# Altium schematic sheet Power - Switch Power.SchDoc("Power - Switch Power")
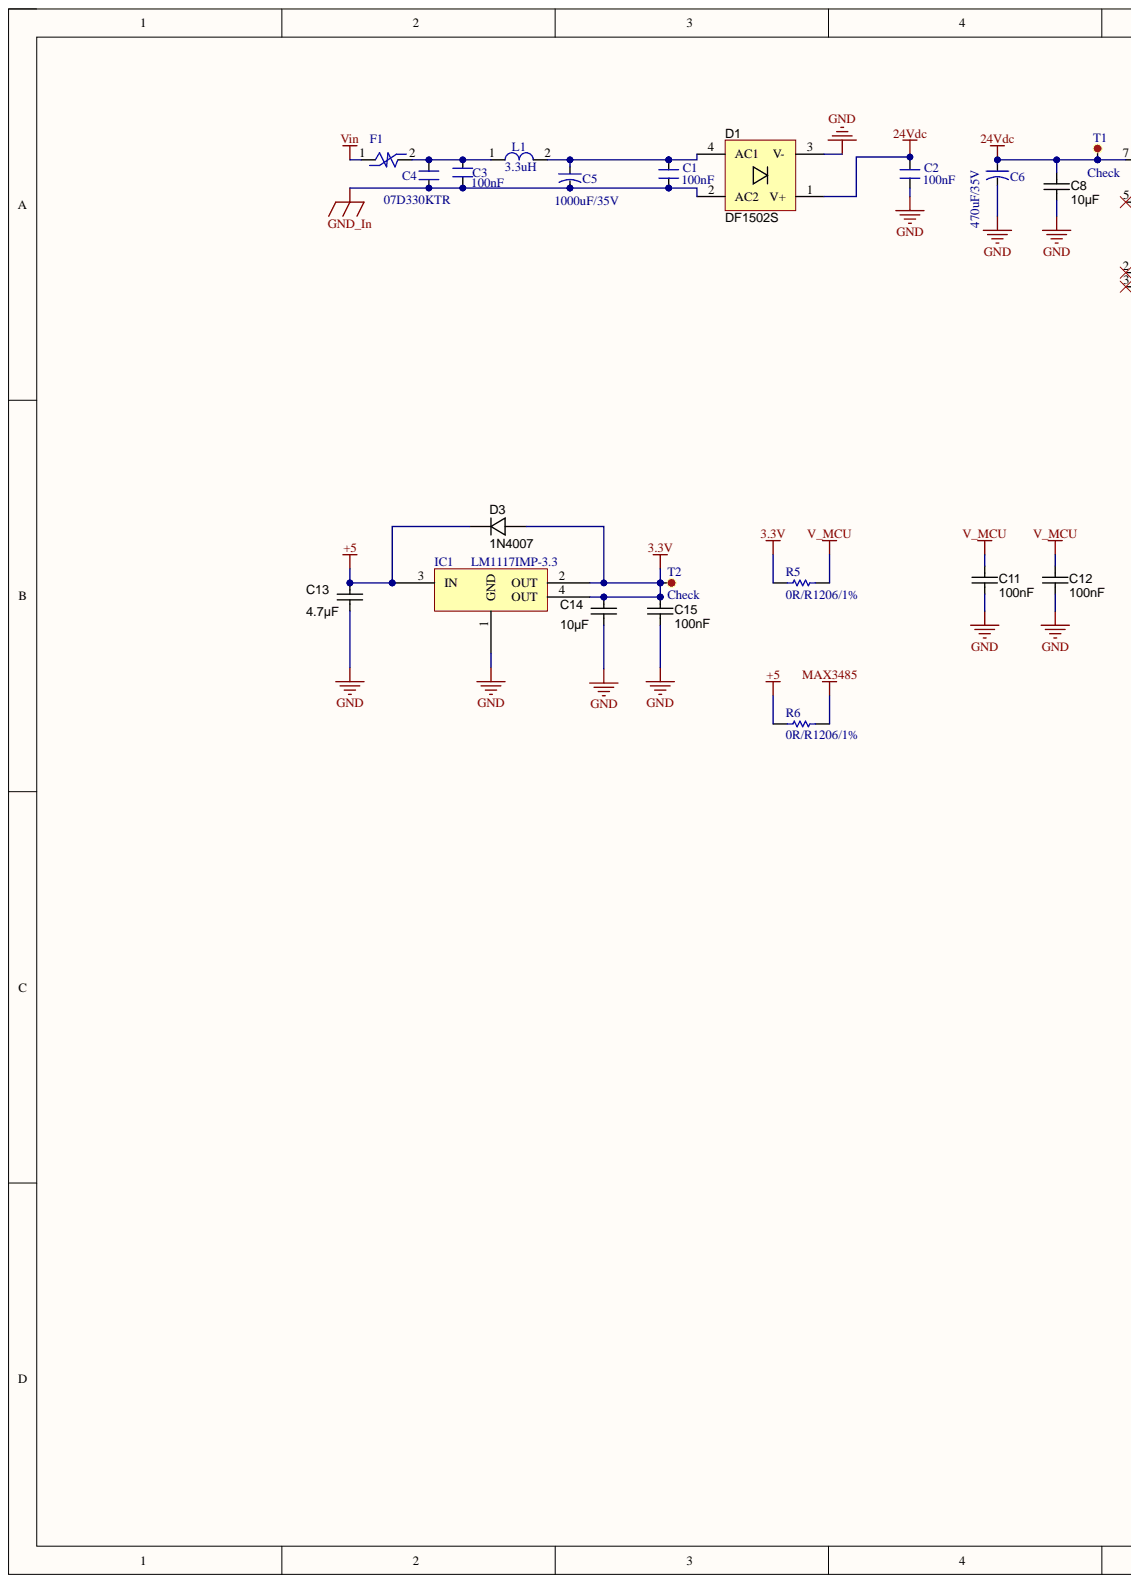
[diagram p0: Power - Switch Power.SchDoc, left]
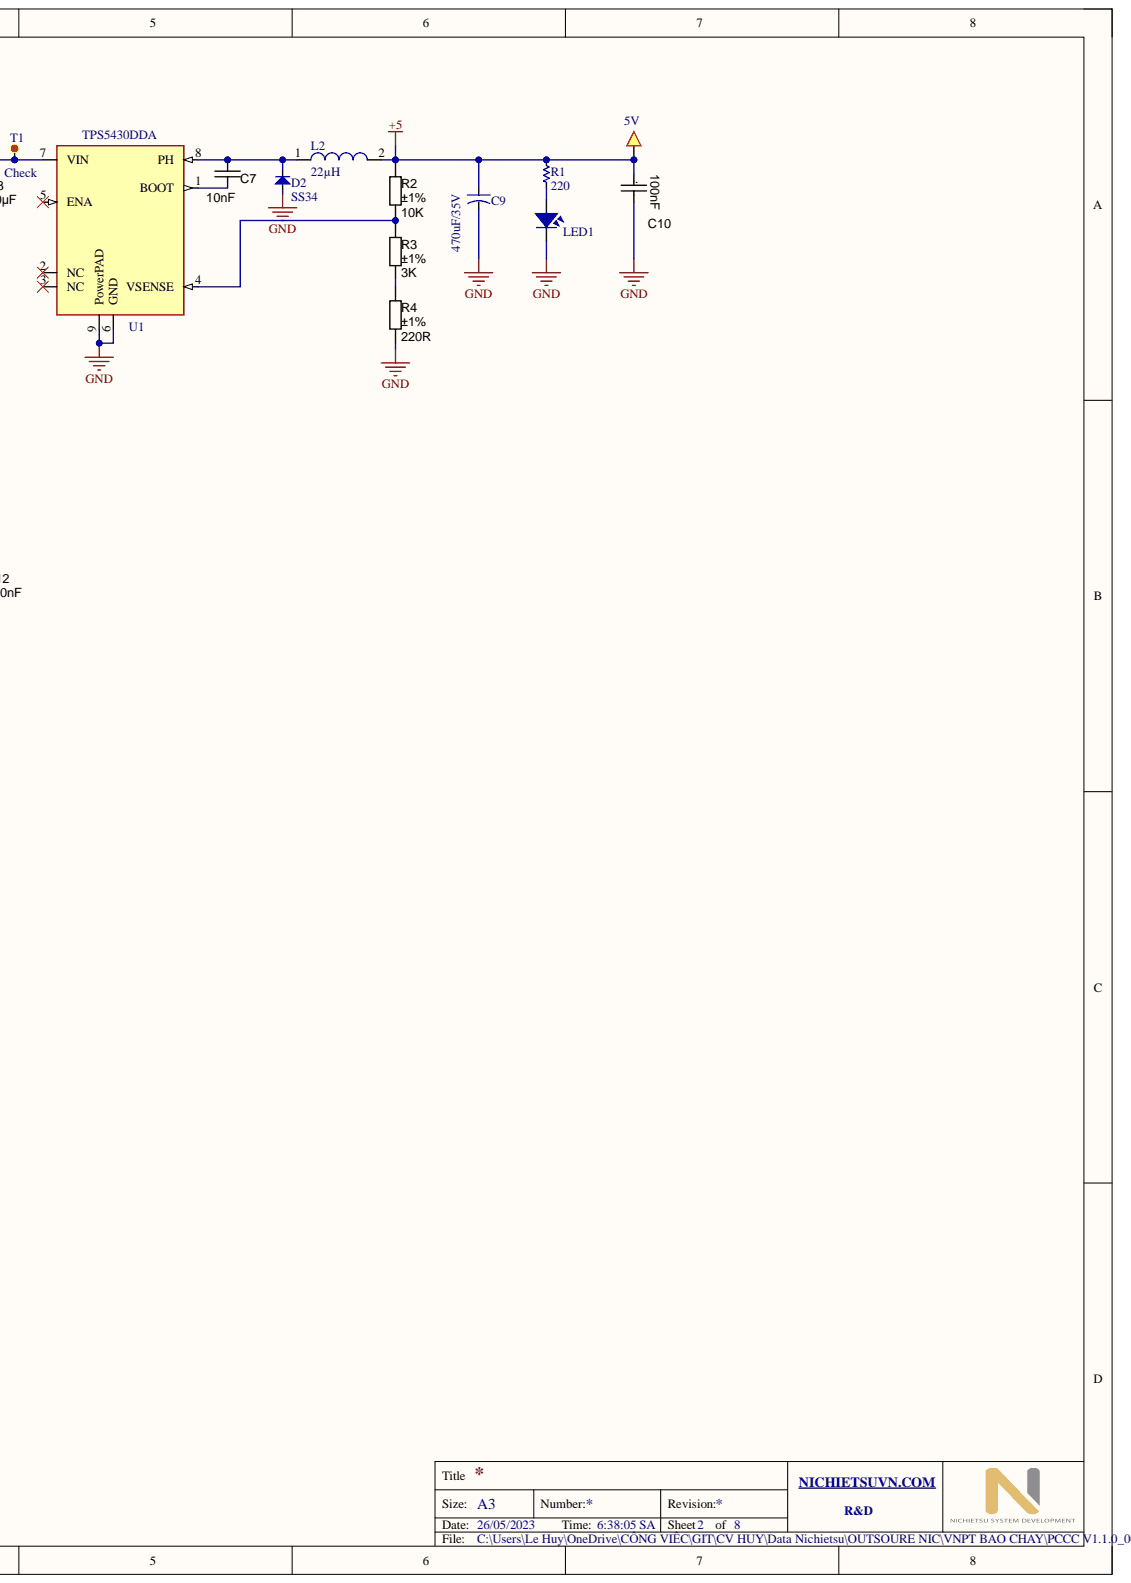
[diagram p1: Power - Switch Power.SchDoc, right]

## Parts
C1  100nF  [CN-SMD-1206]
C2  100nF  [CN-SMD-1206]
C3  100nF  [CN2.5]
C4  07D330KTR  [CN2-T]
C5  1000uF/35V  [CA-ALU-5L]
C6  470uF/35V  [CA-ALU-6.3]
C7  10nF  [CAPC1608X90N]
C8  10µF  [CAPC2012X135N]
C9  470uF/35V  [CA-ALU-6.3]
C10  100nF  [CAPC2012X135N]
C11  100nF  [CAPC1608X90N]
C12  100nF  [CAPC1608X90N]
C13  4.7µF  [CAPC2012X135N]
C14  10µF  [CAPC2012X135N]
C15  100nF  [CAPC1608X90N]
Check1  5V  [SMD-2]
D1  DF1502S  [DIODE_DF_S]
D2  SS34  [DIO-SMD-106]
D3  1N4007  [DO-214AC]
F1  RUEF185  [RUEF165]  (value not drawn)
IC1  LM1117IMP-3.3  [SOT223]
L1  3.3uH  [L-SMD-M-7.3x4.5]
L2  22µH  [IND_COIL_8D43]
LED1  LED  [LED-0805-Blue]
R1  220  [RES-SMD-0603]
R2  value 10K  Tolerance: ±1%  [RESC1608X55N]  (hidden comment: 10K_0603_1%)
R3  value 3K  Tolerance: ±1%  [RESC1608X55N]  (hidden comment: 3K_0603_0.5%)
R4  value 220R  Tolerance: ±1%  [RESC1608X55N]  (hidden comment: 220R_0603_1%)
R5  0R/R1206/1%  [R1206]
R6  0R/R1206/1%  [R1206]
T1  Check  [SMD_1.5]
T2  Check  [SMD_1.5]
U1  TPS5430DDA  [TPS5430]

## Nets
3.3V: C14.2 C15.1 D3.A IC1.2(OUT) IC1.4(OUT) R5.1 T2.1
24Vdc: C2.2 C6.1 C8.2 D1.1(V+) T1.1 U1.7(VIN)
+5: C9.1 C10.1 C13.2 Check1.1 D3.C IC1.3(IN) L2.2 R1.1 R2.2 R6.1
GND: C2.1 C6.2 C8.1 C9.2 C10.2 C11.2 C12.2 C13.1 C14.1 C15.2 D1.3(V-) D2.1 IC1.1(GND) LED1.2 R4.1 U1.6(GND) U1.9(PowerPAD)
GND_In: C1.1 C3.1 C4.1 C5.2 D1.2(AC2)
MAX3485: R6.2
V_MCU: C11.1 C12.1 R5.2
Vin: F1.1
NetC1_2: C1.2 C5.1 D1.4(AC1) L1.2
NetC3_2: C3.2 C4.2 F1.2 L1.1
NetC7_1: C7.1 U1.1(BOOT)
NetC7_2: C7.2 D2.2 L2.1 U1.8(PH)
NetLED1_1: LED1.1 R1.2
NetR2_1: R2.1 R3.2 U1.4(VSENSE)
NetR3_1: R3.1 R4.2

Not connected: U1 2(NC) 3(NC) 5(ENA)

## Library data of the parts
C1-C4 = CN: Value: 33pF; Component Kind: Standard; Library Name: thu vien 3d.IntLib
C5 C6 C9 = CN-ALU-DIP: Capacitor (Semiconductor SIM Model); Value: 104; Component Kind: Standard; LatestRevisionDate: 17-Jul-2002; LatestRevisionNote: Re-released for DXP Platform.; Library Name: thu vien 3d.IntLib; PackageReference: C1206; Publisher: Altium Limited
C7 = CAPC_10000pF_0603_50V_10%: 10000pF ±10% 50V Ceramic Capacitor X7R 0603 (1608 Metric); Manufacturer 1: TDK Corporation; Manufacturer Part Number 1: CGA3E2X7R1H103K080AA; Pcs 2: 10; Component Kind: Standard; Operating Temperature: -55°C ~ 125°C; Package / Case: 0603 (1608 Metric); Price 2: 1900; Ratings: AEC-Q200; Supplier 1: Digi-Key; Supplier 2: https://www.thegioiic.com; Supplier Part Number 1: 445-5662-6-ND; Supplier Part Number 2: https://www.thegioiic.com/products/tu-gom-0603-10nf-50v; Temperature Coefficient: X7R; Tolerance: ±10%; Value: 10nF; Voltage - Rated: 50V; Library Name: SangMV.SchLib
C8 = CAPC_10uF_0805_X7R_25V_10%: 10µF ±10% 50V Ceramic Capacitor X7R 1206 (3216 Metric); Manufacturer 1: Taiyo Yuden; Manufacturer Part Number: TMK316AB7106KLHT; Pcs 2: 10; Voltage - Rated: 25V; Component Kind: Standard; Library Name: All Library.SchLib; Operating Temperature: -55°C ~ 125°C; Package / Case: 0805 (2012 metric); Price 2: 5800; Ratings: AEC-Q200; Supplier 1: Digi-Key; Supplier 2: https://www.thegioiic.com; Supplier Part Number 1: 587-3485-6-ND; Supplier Part Number 2: https://www.thegioiic.com/products/tu-gom-0805-10uf-25v; Temperature Coefficient: X7R; Tolerance: ±10%; Value: 10µF
C10 = CAPC_100nF_0805_X7R_50V_10%: 0.1µF ±10% 50V Ceramic Capacitor X7R 0805 (2012 Metric); Manufacturer 1: Samsung Electro-Mechanics; Manufacturer Part Number: CL21B104KBFXPJE; Pcs 2: 10; Component Kind: Standard; Operating Temperature: -55°C ~ 125°C; Package / Case: 0805 (2012 metric); Price 2: 2000; Ratings: AEC-Q200; Supplier 1: Digi-Key; Supplier 2: https://www.thegioiic.com; Supplier Part Number 1: 1276-CL21B104KBFXPJEDKR-ND; Supplier Part Number 2: https://www.thegioiic.com/products/tu-gom-0805-100nf-0-1uf-50v; Temperature Coefficient: X7R; Tolerance: ±10%; Value: 100nF; Voltage - Rated: 50V; Library Name: SangMV.SchLib
C11 C12 C15 = CAPC_100nF_0603_X7R_50V_10%: 0.1µF ±10% 50V Ceramic Capacitor X7R 0603 (1608 Metric); Manufacturer 1: TDK Corporation; Manufacturer Part Number 1: CGA3E2X7R1H104K080AA; Pcs 2: 10; Applications: Automotive; Component Kind: Standard; Library Name: All Library.SchLib; Operating Temperature: -55°C ~ 125°C; Package / Case: 0603 (1608 Metric); Packaging: Digi-Reel®; Price 2: 1800; Ratings: AEC-Q200; Supplier 1: Digi-Key; Supplier 2: www.thegioiic.com; Supplier Part Number 1: 445-5666-6-ND; Supplier Part Number 2: https://www.thegioiic.com/products/tu-gom-0603-100nf-0-1uf-50v; Temperature Coefficient: X7R; Tolerance: ±10%; Value: 100nF; Voltage - Rated: 50V
C13 C14 = CAPC_4.7uF_0805_X7R_50V_10%: 4.7µF ±10% 50V Ceramic Capacitor X7R 0805 (2012 Metric); Manufacturer 1: TDK Corporation; Manufacturer Part Number: CGA4J1X7R1H475K125AE; Pcs 2: 1; Component Kind: Standard; Library Name: All Library.SchLib; Operating Temperature: -55°C ~ 125°C; Package / Case: 0805 (2012 metric); Price 2: 680; Ratings: AEC-Q200; Supplier 1: Digi-Key; Supplier 2: https://www.thegioiic.com; Supplier Part Number 1: 445-181410-6-ND; Supplier Part Number 2: https://www.thegioiic.com/products/tu-gom-0805-4-7uf-50v; Temperature Coefficient: X7R; Tolerance: ±10%; Voltage - Rated: 50V
  C13: Value: 4.7µF
  C14: Value: 10µF
Check1 T1 T2 = Test: WR-PHD 2.54 mm Angled Socket Header; Manufacturer: W¨¹rth Elektronik eiSos GmbH; partNo: 613002143121; ComponentLink1 Description: Manufacturer; ComponentLink2 Description: Datasheet; NoPins: 02; Component Kind: Standard; ComponentLink1URL: http://www.we-online.com; ComponentLink2URL: http://katalog.we-online.de/em/datasheet/6130xx143121.pdf; Created Date: 29 March 2013; Created by: Karrer Zheng
  T1 T2: Library Name: All Library.SchLib
  Check1: Library Name: Nichietsuvn.SchLib
D1 = DIODE_DF1502S: Bridge Rectifier Single Phase Standard 200V Surface Mount DF-S; Manufacturer 1: Diodes Incorporated; Manufacturer Part Number 1: DF1502S-T; Pcs 2: 1; Ratings: 200V; 1.5A; Component Kind: Standard; Diode Type: Standard; Mounting Type: Surface Mount; Package / Case: 4-SMD, Gull Wing; Price 2: 3500; Supplier 1: Digi-Key; Supplier 2: https://www.thegioiic.com; Supplier Part Number 1: DF1502SDIDKR-ND; Supplier Part Number 2: https://www.thegioiic.com/products/df1502s-cau-chinh-luu-200v-1-5a; Library Name: PCB_ESP32_Extern.IntLib
D2 = Diode SMD: Default Diode; Code - JEDEC: DO-214-AB; Value: 1N5007; Component Kind: Standard; Library Name: thu vien 3d.IntLib; Published: 8-Jun-2000; Revision: July-2002: Re-released for DXP Platform.; Publisher: Altium Limited
D3 = DIODE_1N4007: Diode  Standard Rectifier SMD 1000V 1A SMA; Manufacturer 1: Micro Commercial Co; Manufacturer Part Number 1: SM4007PL-TP; Pcs 2: 1; Ratings: 1000V; 1A; Speed: Standard Recovery >500ns, > 200mA (Io); Component Kind: Standard; Diode Type: Standard; Library Name: All Library.SchLib; Mounting Type: Surface Mount; Package / Case: DO-214AC, SMA; Price 2: 1000; Supplier 1: Digi-Key; Supplier 2: https://www.thegioiic.com; Supplier Part Number 1: SM4007PL-TPMSDKR-ND; Supplier Part Number 2: https://www.thegioiic.com/products/1n4007-m7-diode-chinh-luu-1a-1kv
IC1 = IC_LM1117IMP-3.3: Linear Voltage Regulator IC  1 Output  800mA SOT-223; Manufacturer: Texas Instruments; Manufacturer Part Number: LM1117IMP-3.3/NOPB; Pcs 2: 1; Protection Features: Over Current, Over Temperature; PSRR: 75dB (120Hz); Voltage - Input (Max): 15V; Voltage Dropout (Max): 1.2V @ 800mA; Component Kind: Standard; Current - Output: 800mA; Library Name: All Library.SchLib; Operating Temperature: -40°C ~ 125°C; Price 2: 11000; Supplier 1: Digi-Key; Supplier 2: https://www.thegioiic.com; Supplier Device Package: SOT-223; Supplier Part Number 1: LM1117IMP-3.3/NOPBDKR-ND; Supplier Part Number 2: https://www.thegioiic.com/products/lm1117imp-3-3-nopb-ic-on-ap-3-3v-800ma
L1 = L-SMD-1: Manufacturer: Wurth Elektronik; partNo: 78477010; Inductance: 1.0 μH; Component Kind: Standard; ComponentLink1Description: Manufacturer; ComponentLink1URL: http://www.we-online.de; ComponentLink2Description: Datasheet; ComponentLink2URL: http://katalog.we-online.de/pbs/datasheet/78477010.pdf; Created Date: Nov.2015; Library Name: thu vien 3d.IntLib; Created by: Baojun Chen
L2 = INDC_SMD_22uH_8D43: 22µH Shielded Wirewound Inductor 950mA 380mOhm Max Nonstandard; Manufacturer 1: Yageo; Current Rating (Amps): 3.25 A; Pcs 2: 1; Ratings: None AEC-Q200; Component Kind: Standard; Operating Temperature: -40°C ~ 125°C; Price 2: 2000; Supplier 1: Digi-Key; Supplier 2: https://www.thegioiic.com/; Supplier Part Number 2: https://www.thegioiic.com/products/cuon-cam-dan-smd-8d43-220-22uh-3-25a; Value: 22µH; Library Name: SangMV.SchLib
LED1 = LED SMD: Value: LED 3 - 5 ly 2 Colour; Component Kind: Standard; Library Name: thu vien 3d.IntLib
R1 = Res-SMD: Resistor; Manufacturer: Generic Components; Class: Passive; Component Kind: Standard; Library Name: thu vien 3d.IntLib; Published: 08/06/2000; Publisher: Altium Hobart Technology Centre
R2 = RES_10K_0603_1%: 10 kOhms ±1% 0.1W, 1/10W Chip Resistor 0603 (1608 Metric) Anti-Sulfur, Automotive AEC-Q200, Moisture Resistant Thin Film; Manufacturer: Stackpole Electronics Inc; Manufacturer Part Number: RMCF0603FT10K0; Pcs 2: 50; Temperature Coefficient: ±100ppm/°C; Component Kind: Standard; Operating Temperature: -55°C ~ 155°C; Package / Case: 0603 (1608 Metric); Power (Watts): 1/10W; Price 2: 2000; Ratings: Automotive AEC-Q200; Supplier 1: Digi-Key; Supplier 2: www.thegioiic.com; Supplier Part Number 1: RMCF0603FT10K0DKR-ND; Supplier Part Number 2: https://www.thegioiic.com/products/dien-tro-10-kohm-0603-1; Library Name: SangMV.SchLib
R3 = RES_3K_0603_1%: 3 kOhms ±1% 0.1W, 1/10W Chip Resistor 0603 (1608 Metric) Automotive AEC-Q200 Thick Film; Manufacturer: Stackpole Electronics Inc; Manufacturer Part Number: RMCF0603FT3K00; Pcs 2: 50; Temperature Coefficient: ±100ppm/°C; Component Kind: Standard; Operating Temperature: -55°C ~ 155°C; Package / Case: 0603 (1608 Metric); Power (Watts): 1/10W; Price 2: 2000; Ratings: AEC-Q200; Supplier 1: Digi-Key; Supplier 2: www.thegioiic.com; Supplier Part Number 1: RMCF0603FT3K00DKR-ND; Supplier Part Number 2: https://www.thegioiic.com/products/dien-tro-3-kohm-0603-1; Library Name: SangMV.SchLib
R4 = RES_220R_0603_1%: 220 Ohms ±1% 0.1W, 1/10W Chip Resistor 0603 (1608 Metric) Automotive AEC-Q200 Thick Film; Manufacturer: Stackpole Electronics Inc; Manufacturer Part Number: RMCF0603FT220R; Pcs 2: 50; Temperature Coefficient: ±100ppm/°C; Component Kind: Standard; Composition: Thick Film; Operating Temperature: -55°C ~ 155°C; Package / Case: 0603 (1608 Metric); Power (Watts): 0.1W, 1/10W; Price 2: 2000; Ratings: AEC-Q200; Supplier 1: Digi-Key; Supplier 2: www.thegioiic.com; Supplier Part Number 1: RMCF0603FT220RDKR-ND; Supplier Part Number 2: https://www.thegioiic.com/products/dien-tro-220-ohm-0603-1; Library Name: SangMV.SchLib
R5 R6 = RES_0R/R1206/1%: RES SMD 0.0 OHM JUMPER 1/4W 1206; Manufacturer: Bourns Inc.; Manufacturer Part Number: CR1206-J/-000ELF; Power (Watts): 0.25W, 1/4W; Resistance (Ohms): 0.0; Temperature Coefficient: -250/ +500ppm/°C; Category: Resistors; Component Kind: Standard; ComponentLink1Description: http://www.bourns.com/data/global/pdfs/CHPREZTR.pdf; ComponentLink1URL: http://www.bourns.com/data/global/pdfs/CHPREZTR.pdf; Composition: Thick Film; Features: Moisture Resistant; Height: 0.030" (0.75mm); Library Name: All Library.SchLib; Number of Terminations: 2; Operating Temperature: -55°C ~ 155°C; Package / Case: 1206 (3216 Metric); Packaging: Tape & Reel (TR); Pricing 1: 5000=0.00931, 10000=0.00809, 25000=0.00711, 50000=0.00652, 125000=0.00638 (USD); RoHS: RoHS Compliant By Exemption; Size / Dimension: 0.126" L x 0.063" W (3.20mm x 1.60mm); Stock 1: 80000; Supplier 1: Digi-Key; Supplier Device Package: 1206; Supplier Part Number 1: CR1206-J/-000ELFTR-ND; Tolerance: Jumper
F1 = RUEF185: Component Kind: Standard; Library Name: All Library.SchLib
U1 = TPS5430DDA: Component Kind: Standard; Library Name: Nichietsuvn.SchLib
